# Altium schematic sheet BUTTON_LED.SchDoc(BUTTON_LED)
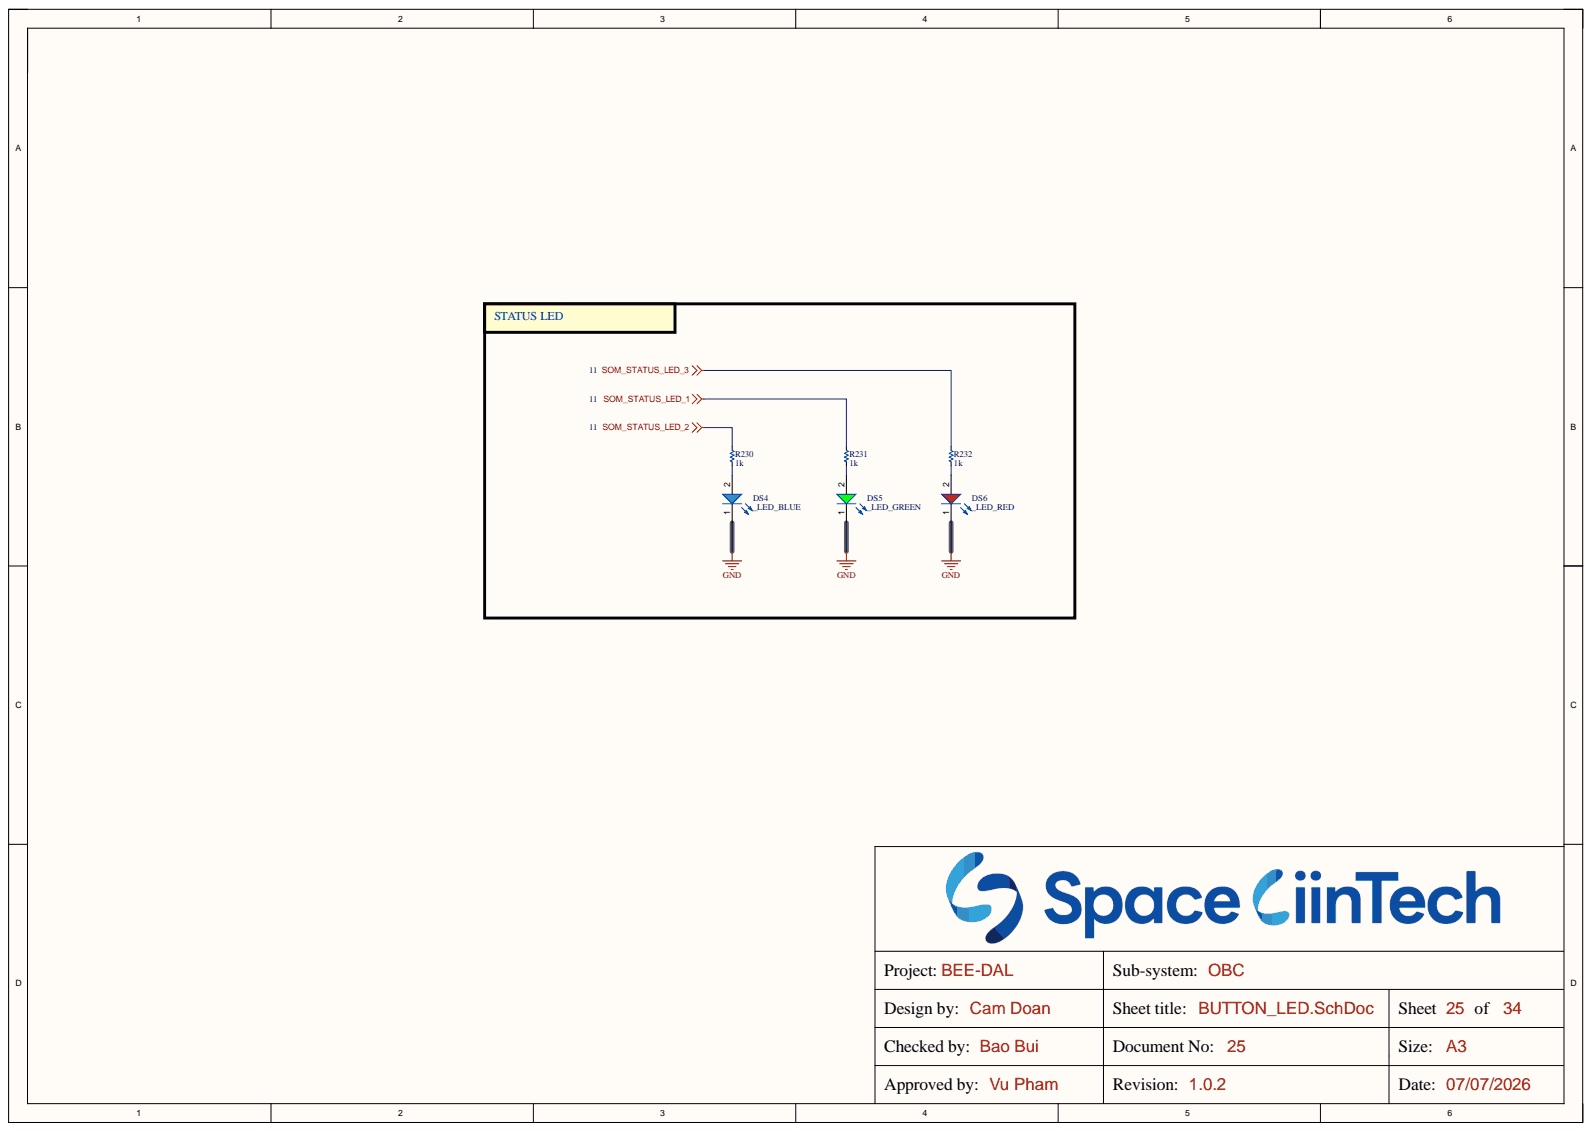
[diagram p0: BUTTON_LED.SchDoc]

## Parts
DS4  _LED_BLUE  [LED_0603]
DS5  _LED_GREEN  [LED_0603]
DS6  _LED_RED  [LED_0603]
R230  1k  [RES_C_1005X40]
R231  1k  [RES_C_1005X40]
R232  1k  [RES_C_1005X40]

## Nets
GND: DS4.1 DS5.1 DS6.1
SOM_STATUS_LED_1: R231.2
SOM_STATUS_LED_2: R230.2
SOM_STATUS_LED_3: R232.2
NetDS4_2: DS4.2 R230.1
NetDS5_2: DS5.2 R231.1
NetDS6_2: DS6.2 R232.1

## Library data of the parts
DS4 = LED_BLUE: LED BLUE CLEAR 0603 SMD; Component Kind: Standard; Library Name: IFBschelib.SchLib
DS5 = LED_GREEN: LED GREEN CLEAR 0603 SMD; Component Kind: Standard; Library Name: IFBschelib.SchLib
DS6 = LED_RED: LED RED CLEAR 0603 SMD; Component Kind: Standard; Library Name: IFBschelib.SchLib
R230-R232 = _RES_B_2: RES; Component Kind: Standard; Library Name: LABLIB.SchLib
